# Altium schematic sheet NRF_remote_control_main.SchDoc
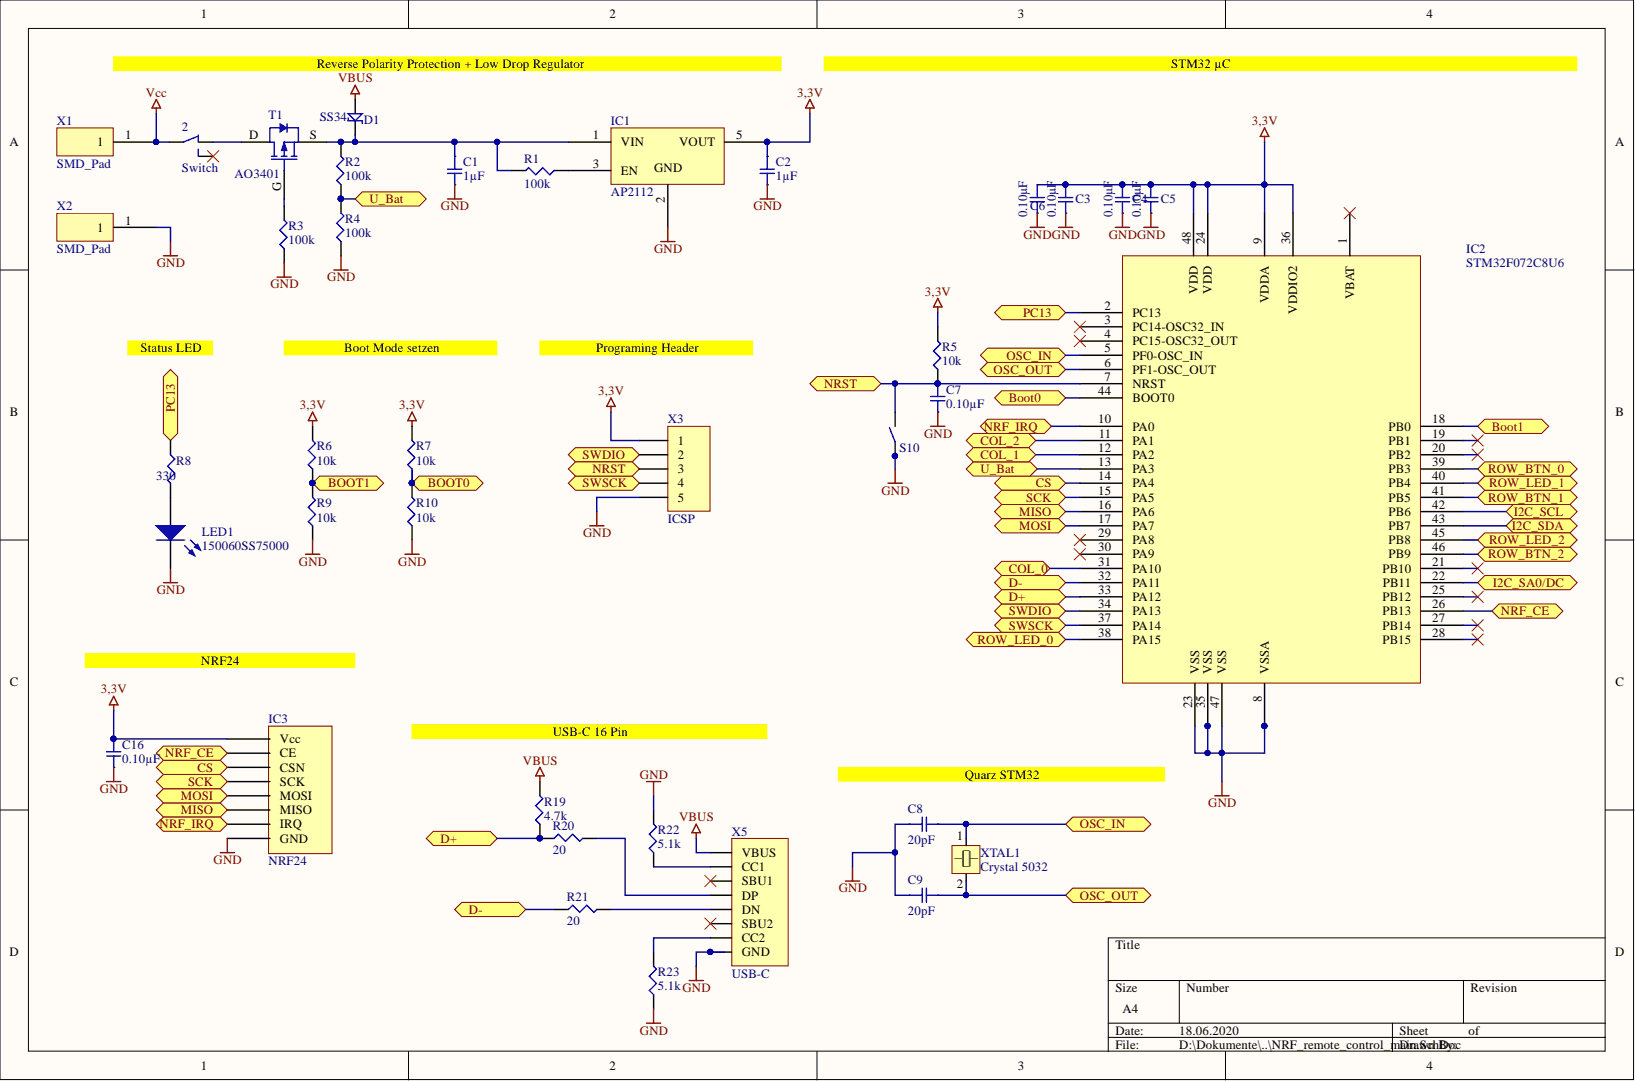
[diagram p0: NRF_remote_control_main.SchDoc]

## Parts
2  Switch  [K3-1296S-J1]
C1  1µF  [SMD-0603C]
C2  1µF  [SMD-0603C]
C3  0.10µF  [SMD-0603C]
C4  0.10µF  [SMD-0603C]
C5  0.10µF  [SMD-0603C]
C6  0.10µF  [SMD-0603C]
C7  0.10µF  [SMD-0603C]
C8  20pF  [SMD-0603C]
C9  20pF  [SMD-0603C]
C16  0.10µF  [SMD-0603C]
D1  SS34  [B240A]
IC1  AP2112  [SOT23-5]
IC2  STM32F072C8U6  [LQFP-48]
IC3  NRF24  [ML01SP4]
LED1  150060SS75000  [0603_A]
R1  100k  [SMD-0603-RES]
R2  100k  [SMD-0603-RES]
R3  100k  [SMD-0603-RES]
R4  100k  [SMD-0603-RES]
R5  10k  [SMD-0603-RES]
R6  10k  [SMD-0603-RES]
R7  10k  [SMD-0603-RES]
R8  330  [SMD-0603-RES]
R9  10k  [SMD-0603-RES]
R10  10k  [SMD-0603-RES]
R19  4.7k  [SMD-0603-RES]
R20  20  [SMD-0603-RES]
R21  20  [SMD-0603-RES]
R22  5.1k  [SMD-0603-RES]
R23  5.1k  [SMD-0603-RES]
S10  Button  [Button5050]  (value not drawn)
T1  AO3401  [SOT23]
X1  SMD_Pad  [SMD_Pad]
X2  SMD_Pad  [SMD_Pad]
X3  ICSP  [TC2030-MCP-NL]
X5  USB-C  [USB-C]
XTAL1  Crystal 5032  [5032]

## Ports
BOOT0, Boot0, BOOT1, Boot1, COL_0, COL_1, COL_2, CS, D+, D-, I2C_SA0/DC, I2C_SCL, I2C_SDA, MISO, MOSI, NRF_CE, NRF_IRQ, NRST, OSC_IN, OSC_OUT, PC13, ROW_BTN_0, ROW_BTN_1, ROW_BTN_2, ROW_LED_0, ROW_LED_1, ROW_LED_2, SCK, SWDIO, SWSCK, U_Bat

## Nets
3,3V: C2.1 C3.1 C4.1 C5.1 C6.1 C16.1 IC1.5(VOUT) IC2.9(VDDA) IC2.24(VDD) IC2.36(VDDIO2) IC2.48(VDD) IC3.Vcc R5.2 R6.2 R7.2 X3.1
GND: C1.2 C2.2 C3.2 C4.2 C5.2 C6.2 C7.2 C8.1 C9.1 C16.2 IC1.2 IC2.8(VSSA) IC2.23(VSS) IC2.35(VSS) IC2.47(VSS) IC3.Gnd(GND) LED1.1 R3.1 R4.1 R9.1 R10.1 R22.2 R23.1 S10.1 X2.1 X3.5 X5.GND
VBUS: D1.A R19.2 X5.VBUS
Vcc: 2.3 X1.1
BOOT0: IC2.44(BOOT0) R7.1 R10.2 ; port BOOT0, port Boot0
BOOT1: IC2.18(PB0) R6.1 R9.2 ; port BOOT1, port Boot1
COL_0: IC2.31(PA10) ; port COL_0
COL_1: IC2.12(PA2) ; port COL_1
COL_2: IC2.11(PA1) ; port COL_2
CS: IC2.14(PA4) IC3.CSN ; port CS
I2C_SA0/DC: IC2.22(PB11) ; port I2C_SA0/DC
I2C_SCL: IC2.42(PB6) ; port I2C_SCL
I2C_SDA: IC2.43(PB7) ; port I2C_SDA
MISO: IC2.16(PA6) IC3.MISO ; port MISO
MOSI: IC2.17(PA7) IC3.MOSI ; port MOSI
NRF_CE: IC2.26(PB13) IC3.CE ; port NRF_CE
NRF_IRQ: IC2.10(PA0) IC3.IRQ ; port NRF_IRQ
NRST: C7.1 IC2.7(NRST) R5.1 S10.2 X3.3 ; port NRST
OSC_IN: C8.2 IC2.5(PF0-OSC_IN) XTAL1.1 ; port OSC_IN
OSC_OUT: C9.2 IC2.6(PF1-OSC_OUT) XTAL1.2 ; port OSC_OUT
PC13: IC2.2(PC13) R8.1 ; port PC13
ROW_BTN_0: IC2.39(PB3) ; port ROW_BTN_0
ROW_BTN_1: IC2.41(PB5) ; port ROW_BTN_1
ROW_BTN_2: IC2.46(PB9) ; port ROW_BTN_2
ROW_LED_0: IC2.38(PA15) ; port ROW_LED_0
ROW_LED_1: IC2.40(PB4) ; port ROW_LED_1
ROW_LED_2: IC2.45(PB8) ; port ROW_LED_2
SCK: IC2.15(PA5) IC3.SCK ; port SCK
SWDIO: IC2.34(PA13) X3.2 ; port SWDIO
SWSCK: IC2.37(PA14) X3.4 ; port SWSCK
U_Bat: IC2.13(PA3) R2.1 R4.2 ; port U_Bat
Net2_1: 2.1 T1.D
NetC1_1: C1.1 D1.K IC1.1(VIN) R1.2 R2.2 T1.S
NetIC1_3: IC1.3(EN) R1.1
NetIC2_32: IC2.32(PA11) R21.1
NetIC2_33: IC2.33(PA12) R19.1 R20.1
NetLED1_2: LED1.2 R8.2
NetR3_2: R3.2 T1.G
NetR20_2: R20.2 X5.DP
NetR21_2: R21.2 X5.DN
NetR22_1: R22.1 X5.CC1
NetR23_2: R23.2 X5.CC2

Not connected: 2 2; IC2 1(VBAT) 3(PC14-OSC32_IN) 4(PC15-OSC32_OUT) 19(PB1) 20(PB2) 21(PB10) 25(PB12) 27(PB14) 28(PB15) 29(PA8) 30(PA9); X5 SBU1

## Library data of the parts
C1 C2 = GCM188R71C105KA64D: CAP CER 1UF 16V X7R 0603; Manufacturer: Murata Electronics North America; @ qty: 0; Minimum Quantity: 1; Tolerance: ±10%; Unit Price (USD): 0.24; Applications: Automotive; Component Kind: Standard; Datasheets: http://www.murata.com/~/media/webrenewal/support/library/catalog/products/capacitor/mlcc/c03e.ashx; Digi-Key Part Number: 490-5241-1-ND; Factory Stock: 0; Features: -; Height - Seated (Max): -; Image: //media.digikey.com/Renders/Murata%20Renders/0603%28GCM188%29.jpg; Lead Spacing: -; Lead Style: -; Library Name: capacitor_murata_0603.IntLib; Mounting Type: Surface Mount, MLCC; Operating Temperature: -55°C ~ 125°C; Package / Case: 0603 (1608 Metric); Packaging: Cut Tape (CT); Part Number: GCM188R71C105KA64D; Part Status: Active; Quantity Available: 9243; Ratings: AEC-Q200; Series: GCM; Size / Dimension: 0.063" L x 0.031" W (1.60mm x 0.80mm); Temperature Coefficient: X7R; Thickness (Max): 0.035" (0.90mm); Value: 1µF; Voltage: 16V
C3-C9 C16 = GCM188R71C104KA37J: CAP CER 0.1UF 16V X7R 0603; Manufacturer: Murata Electronics North America; @ qty: 0; Minimum Quantity: 1; Tolerance: ±10%; Unit Price (USD): 0.18; Applications: Automotive; Component Kind: Standard; Datasheets: http://www.murata.com/~/media/webrenewal/support/library/catalog/products/capacitor/mlcc/c03e.ashx; Digi-Key Part Number: 490-8015-1-ND; Factory Stock: 0; Features: -; Height - Seated (Max): -; Image: //media.digikey.com/Renders/Murata%20Renders/0603%28GCM188%29.jpg; Lead Spacing: -; Lead Style: -; Library Name: capacitor_murata_0603.IntLib; Mounting Type: Surface Mount, MLCC; Operating Temperature: -55°C ~ 125°C; Package / Case: 0603 (1608 Metric); Packaging: Cut Tape (CT); Part Number: GCM188R71C104KA37J; Part Status: Active; Quantity Available: 17844; Ratings: AEC-Q200; Series: GCM; Size / Dimension: 0.063" L x 0.031" W (1.60mm x 0.80mm); Temperature Coefficient: X7R; Thickness (Max): 0.035" (0.90mm); Voltage: 16V
  C3-C7 C16: Value: 0.10µF
  C8 C9: Value: 20pF
D1 = B240A: Shottkydiode; Component Kind: Standard; Library Name: MyLibs.SchLib
LED1 = 150060SS75000: SMD mono-color Chip LED, WL-SMCW, Super Red; Color: Super Red; Component Kind: Standard; ComponentLink1Description: Manufacturer URL; ComponentLink1URL: http://www.we-online.com; ComponentLink2Description: Datasheet; ComponentLink2URL: http://katalog.we-online.com/led/datasheet/150060SS75000.pdf; PackageReference: 0603_A; PartNumber: 150060SS75000; Library Name: WL-SMCW SMD chip LED top view mono-color waterclear.IntLib
R1-R4 R19 = ERJ-3EKF4701V: RES SMD 4.7K OHM 1% 1/10W 0603; Manufacturer: Panasonic Electronic Components; @ qty: 1500; Factory Stock: 0; Minimum Quantity: 1; Power (Watts): 0.1W, 1/10W; Temperature Coefficient: ±100ppm/°C; Unit Price (USD): 0.00379; Component Kind: Standard; Composition: Thick Film; Datasheets: http://industrial.panasonic.com/www-cgi/jvcr13pz.cgi?E+PZ+3+AOA0002+ERJ3EKF4701V+7+WW; Digi-Key Part Number: P4.70KHCT-ND; Failure Rate: -; Features: Automotive AEC-Q200; Height - Seated (Max): 0.022" (0.55mm); Image: //media.digikey.com/Photos/Panasonic%20Photos/MFG_ERJ-P6W%20Series.jpg; Library Name: Panasonic_ERJ_0603.IntLib; Number of Terminations: 2; Operating Temperature: -55°C ~ 155°C; Package / Case: 0603 (1608 Metric); Packaging: Cut Tape (CT); Part Number: ERJ-3EKF4701V; Part Status: Active; Quantity Available: 999380; Series: ERJ; Size / Dimension: 0.063" L x 0.031" W (1.60mm x 0.80mm); Supplier Device Package: 0603; Tolerance: ±1%
  R1-R4: Value: 100k
  R19: Value: 4.7k
R5-R7 R9 R10 = ERJ-3EKF1002V: RES SMD 10K OHM 1% 1/10W 0603; Manufacturer: Panasonic Electronic Components; @ qty: 1500; Factory Stock: 0; Minimum Quantity: 1; Power (Watts): 0.1W, 1/10W; Temperature Coefficient: ±100ppm/°C; Unit Price (USD): 0.00379; Component Kind: Standard; Composition: Thick Film; Datasheets: http://industrial.panasonic.com/www-cgi/jvcr13pz.cgi?E+PZ+3+AOA0002+ERJ3EKF1002V+7+WW; Digi-Key Part Number: P10.0KHCT-ND; Failure Rate: -; Features: Automotive AEC-Q200; Height - Seated (Max): 0.022" (0.55mm); Image: //media.digikey.com/Photos/Panasonic%20Photos/MFG_ERJ-P6W%20Series.jpg; Library Name: Panasonic_ERJ_0603.IntLib; Number of Terminations: 2; Operating Temperature: -55°C ~ 155°C; Package / Case: 0603 (1608 Metric); Packaging: Cut Tape (CT); Part Number: ERJ-3EKF1002V; Part Status: Active; Quantity Available: 6703141; Series: ERJ; Size / Dimension: 0.063" L x 0.031" W (1.60mm x 0.80mm); Supplier Device Package: 0603; Tolerance: ±1%; Value: 10k
R8 = ERJ-3EKF3300V: RES SMD 330 OHM 1% 1/10W 0603; Manufacturer: Panasonic Electronic Components; @ qty: 1500; Factory Stock: 0; Minimum Quantity: 1; Power (Watts): 0.1W, 1/10W; Temperature Coefficient: ±100ppm/°C; Unit Price (USD): 0.00379; Component Kind: Standard; Composition: Thick Film; Datasheets: http://industrial.panasonic.com/www-cgi/jvcr13pz.cgi?E+PZ+3+AOA0002+ERJ3EKF3300V+7+WW; Digi-Key Part Number: P330HCT-ND; Failure Rate: -; Features: Automotive AEC-Q200; Height - Seated (Max): 0.022" (0.55mm); Image: //media.digikey.com/Photos/Panasonic%20Photos/MFG_ERJ-P6W%20Series.jpg; Library Name: Panasonic_ERJ_0603.IntLib; Number of Terminations: 2; Operating Temperature: -55°C ~ 155°C; Package / Case: 0603 (1608 Metric); Packaging: Cut Tape (CT); Part Number: ERJ-3EKF3300V; Part Status: Active; Quantity Available: 670984; Series: ERJ; Size / Dimension: 0.063" L x 0.031" W (1.60mm x 0.80mm); Supplier Device Package: 0603; Tolerance: ±1%; Value: 330
R20 R21 = ERJ-3EKF20R0V: RES SMD 20 OHM 1% 1/10W 0603; Manufacturer: Panasonic Electronic Components; @ qty: 1500; Factory Stock: 0; Minimum Quantity: 1; Power (Watts): 0.1W, 1/10W; Temperature Coefficient: ±100ppm/°C; Unit Price (USD): 0.00379; Component Kind: Standard; Composition: Thick Film; Datasheets: http://industrial.panasonic.com/www-cgi/jvcr13pz.cgi?E+PZ+3+AOA0002+ERJ3EKF20R0V+7+WW; Digi-Key Part Number: P20.0HCT-ND; Failure Rate: -; Features: Automotive AEC-Q200; Height - Seated (Max): 0.022" (0.55mm); Image: //media.digikey.com/Photos/Panasonic%20Photos/MFG_ERJ-P6W%20Series.jpg; Library Name: Panasonic_ERJ_0603.IntLib; Number of Terminations: 2; Operating Temperature: -55°C ~ 155°C; Package / Case: 0603 (1608 Metric); Packaging: Cut Tape (CT); Part Number: ERJ-3EKF20R0V; Part Status: Active; Quantity Available: 92419; Series: ERJ; Size / Dimension: 0.063" L x 0.031" W (1.60mm x 0.80mm); Supplier Device Package: 0603; Tolerance: ±1%; Value: 20
R22 R23 = ERJ-3GEYJ512V: RES SMD 5.1K OHM 5% 1/10W 0603; Manufacturer: Panasonic Electronic Components; @ qty: 1500; Factory Stock: 0; Minimum Quantity: 1; Power (Watts): 0.1W, 1/10W; Temperature Coefficient: ±200ppm/°C; Unit Price (USD): 0.00321; Component Kind: Standard; Composition: Thick Film; Datasheets: http://industrial.panasonic.com/www-cgi/jvcr13pz.cgi?E+PZ+3+AOA0001+ERJ3GEYJ512V+7+WW; Digi-Key Part Number: P5.1KGCT-ND; Failure Rate: -; Features: Automotive AEC-Q200; Height - Seated (Max): 0.022" (0.55mm); Image: //media.digikey.com/Photos/Panasonic%20Photos/MFG_ERJ-P6W%20Series.jpg; Library Name: Panasonic_ERJ_0603.IntLib; Number of Terminations: 2; Operating Temperature: -55°C ~ 155°C; Package / Case: 0603 (1608 Metric); Packaging: Cut Tape (CT); Part Number: ERJ-3GEYJ512V; Part Status: Active; Quantity Available: 285626; Series: ERJ; Size / Dimension: 0.063" L x 0.031" W (1.60mm x 0.80mm); Supplier Device Package: 0603; Tolerance: ±5%; Value: 5.1k
S10 = Button: 6.1x3.7x2.5mm; Component Kind: Standard; Library Name: MyLibs.SchLib
T1 = AO3401: AO3401 MOSFET 30V; Component Kind: Standard; Library Name: MyLibs.SchLib
X5 = USB-C 16P: Component Kind: Standard; Library Name: MyLib.IntLib
XTAL1 = 5032: Component Kind: Standard; Library Name: MyLibs.SchLib
2 = Switch: Component Kind: Standard; Library Name: MyLib.IntLib
IC1 = AP2112: Component Kind: Standard; Library Name: MyLibs.SchLib
IC2 = STM32F072C8U6: Component Kind: Standard; Library Name: MyLibs.SchLib
IC3 = NRF24: Component Kind: Standard; Library Name: MyLibs.SchLib
X1 X2 = SMD_Pad: Component Kind: Standard; Library Name: MyLibs.SchLib
X3 = ICSP: Component Kind: Standard; Library Name: TC2030.IntLib
